# Altium schematic sheet Slave.~(35).~(1).SchDoc(Slave.~(35).~(1))
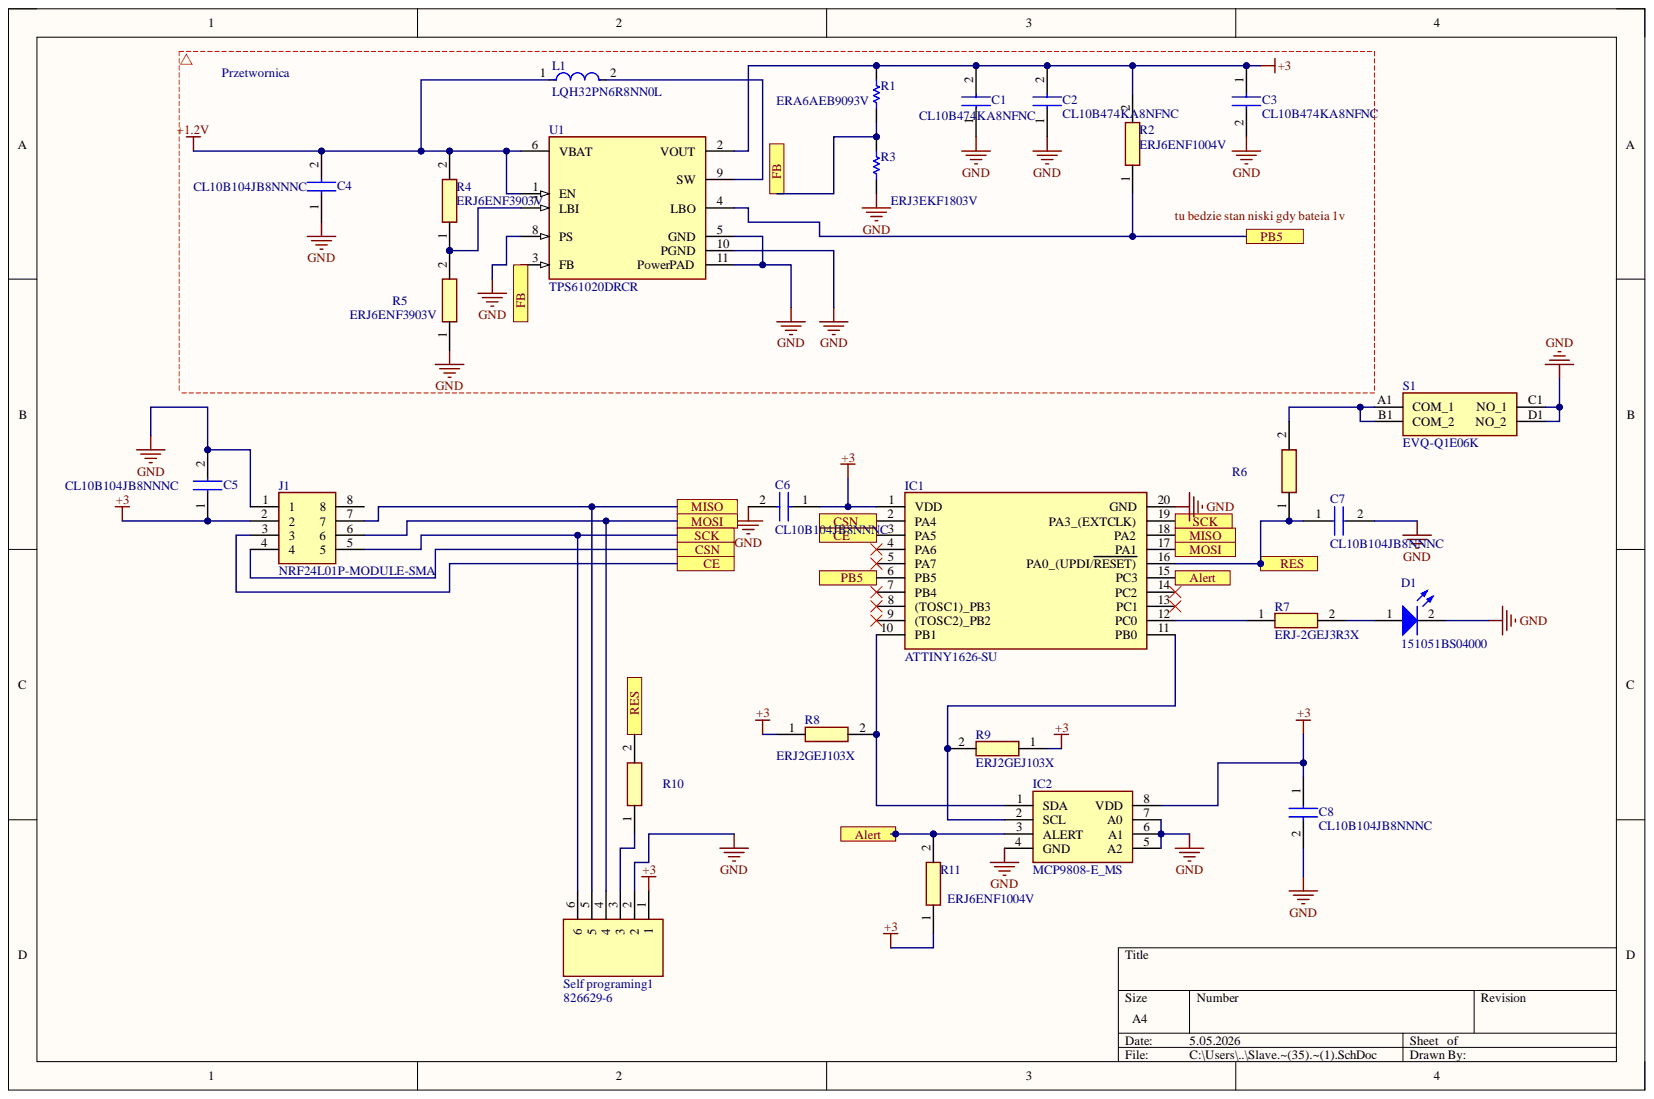
[diagram p0: Slave.~]

## Parts
C1  CL10B474KA8NFNC  [CAPC1608X90N]
C2  CL10B474KA8NFNC  [CAPC1608X90N]
C3  CL10B474KA8NFNC  [CAPC1608X90N]
C4  CL10B104JB8NNNC  [CAPC1608X90N]
C5  CL10B104JB8NNNC  [CAPC1608X90N]
C6  CL10B104JB8NNNC  [CAPC1608X90N]
C7  CL10B104JB8NNNC  [CAPC1608X90N]
C8  CL10B104JB8NNNC  [CAPC1608X90N]
D1  151051BS04000  [WL-TMRC_D5.9H8.7]
IC1  ATTINY1626-SU  [SOIC127P1030X265-20N]
IC2  MCP9808-E_MS  [SOP65P490X110-8N]
J1  NRF24L01P-MODULE-SMA  [NRF24L01PMODULESMA]
L1  LQH32PN6R8NN0L  [FP-LQH32PB_N0-MFG]
R1  ERA6AEB9093V  [RESC2013X60X40NL20T25]
R2  ERJ6ENF1004V  [ERJ6ENF1000V]
R3  ERJ3EKF1803V  [RESC1608X55X30LL15T15]
R4  ERJ6ENF3903V  [ERJ6ENF1000V]
R5  ERJ6ENF3903V  [ERJ6ENF1000V]
R6  ERJ6ENF3903V  [ERJ6ENF1000V]
R7  ERJ-2GEJ3R3X  [ERJ2RKD1004X]
R8  ERJ2GEJ103X  [ERJ2RKD1004X]
R9  ERJ2GEJ103X  [ERJ2RKD1004X]
R10  ERJ6ENF3903V  [ERJ6ENF1000V]
R11  ERJ6ENF1004V  [ERJ6ENF1000V]
S1  EVQ-Q1E06K  [EVQQ1E06K]
Self_programing1  826629-6  [HDRV6W63P0X254_1X6_1524X250X950P]
U1  TPS61020DRCR  [FP-DRC0010J-IPC_A]

## Ports
Alert, CE, CSN, FB, MISO, MOSI, PB5, RES, SCK

## Nets
+1.2V: C4.2 L1.1 R4.2 U1.1(EN) U1.6(VBAT)
+3: C1.2 C2.2 C3.1 C5.1 C6.1 C8.1 IC1.1(VDD) IC2.8(VDD) J1.2 R1.2 R2.2 R8.1 R9.1 R11.1 Self_programing1.1 U1.2(VOUT)
GND: C1.1 C2.1 C3.2 C4.1 C5.2 C6.2 C7.2 C8.2 D1.2 IC1.20(GND) IC2.4(GND) IC2.5(A2) IC2.6(A1) IC2.7(A0) J1.1 R3.1 R5.1 S1.C1(NO_1) S1.D1(NO_2) Self_programing1.2 U1.5(GND) U1.8(PS) U1.10(PGND) U1.11(PowerPAD)
Alert: IC1.15(PC3) IC2.3(ALERT) R11.2 ; port Alert
CE: IC1.3(PA5) J1.3 ; port CE
CSN: IC1.2(PA4) J1.4 ; port CSN
FB: R1.1 R3.2 U1.3(FB) ; port FB
MISO: IC1.18(PA2) J1.7 Self_programing1.5 ; port MISO
MOSI: IC1.17(PA1) J1.6 Self_programing1.4 ; port MOSI
PB5: IC1.6(PB5) R2.1 U1.4(LBO) ; port PB5
RES: C7.1 IC1.16(PA0_(UPDI/RESET)) R6.1 R10.2 ; port RES
SCK: IC1.19(PA3_(EXTCLK)) J1.5 Self_programing1.6 ; port SCK
NetD1_1: D1.1 R7.2
NetIC1_10: IC1.10(PB1) IC2.1(SDA) R8.2
NetIC1_11: IC1.11(PB0) IC2.2(SCL) R9.2
NetIC1_12: IC1.12(PC0) R7.1
NetL1_2: L1.2 U1.9(SW)
NetR4_1: R4.1 R5.2 U1.7(LBI)
NetR6_2: R6.2 S1.A1(COM_1) S1.B1(COM_2)
NetR10_1: R10.1 Self_programing1.3
tu bedzie stan niski gdy bateia 1v:

Not connected: IC1 4(PA6) 5(PA7) 7(PB4) 8((TOSC1)_PB3) 9((TOSC2)_PB2) 13(PC1) 14(PC2); J1 8

## Library data of the parts
C1-C3 = CL10B474KA8NFNC: Capacitor; Manufacturer_Name: SAMSUNG; Arrow Part Number: CL10B474KA8NFNC; Arrow Price/Stock: https://www.arrow.com/en/products/cl10b474ka8nfnc/samsung-electro-mechanics; Component Kind: Standard; Datasheet Link: https://www.mouser.com/datasheet/2/585/MLCC-1837944.pdf; Height: 0.9mm; Manufacturer_Part_Number: CL10B474KA8NFNC; Simulation: C_Capacitor_Default
C4-C8 = CL10B104JB8NNNC: Capacitor; Manufacturer_Name: SAMSUNG; Arrow Part Number: CL10B104JB8NNNC; Arrow Price/Stock: https://www.arrow.com/en/products/cl10b104jb8nnnc/samsung-electro-mechanics?region=nac; Component Kind: Standard; Datasheet Link: https://datasheet.datasheetarchive.com/originals/distributors/Datasheets_SAMA/dd149ce8a6679b37799cedb31427d43d.pdf; Height: 0.9mm; Manufacturer_Part_Number: CL10B104JB8NNNC; Mouser Part Number: 187-CL10B104JB8NNNC; Mouser Price/Stock: https://www.mouser.co.uk/ProductDetail/Samsung-Electro-Mechanics/CL10B104JB8NNNC?qs=349EhDEZ59oLEw5wc1qvGw%3D%3D
D1 = CMP-1488-00009-2: NexarPartId: 29717812; Color: Blue; Dominant Wavelength: 470nm; Forward Current: 100mA; Forward Voltage: 3.2V; Lens Size: 5mm; Lens Style: Circular, Diffused, Round; Luminous Intensity: 500mcd; Max Reverse Voltage (DC): 5V; Peak Wavelength: 465nm; Test Current: 20mA; Viewing Angle: 40°; Case/Package: Radial; Component Kind: Standard; Height: 8.6mm; Length: 5mm; Library Name: Altium Content Vault; Max Operating Temperature: 85°C; Min Operating Temperature: -40°C; Mount: Through Hole; Packaging: Bulk; REACH SVHC: Unknown; RoHS: Compliant; Wavelength: 470nm; Width: 5mm
IC1 = ATTINY1626-SU: Integrated Circuit; Manufacturer_Name: Microchip; Arrow Part Number: ATTINY1626-SU; Arrow Price/Stock: https://www.arrow.com/en/products/attiny1626-su/microchip-technology?region=nac; Component Kind: Standard; Datasheet Link: http://ww1.microchip.com/downloads/en/DeviceDoc/ATtiny1624-26-27-DataSheet-DS40002234A.pdf; Height: 2.65mm; Manufacturer_Part_Number: ATTINY1626-SU
IC2 = MCP9808-E_MS: Integrated Circuit; Manufacturer_Name: Microchip; Manufacturer_Part_Number: MCP9808-E/MS; Arrow Part Number: MCP9808-E/MS; Arrow Price/Stock: https://www.arrow.com/en/products/mcp9808-ems/microchip-technology?region=nac; Component Kind: Standard; Datasheet Link: https://datasheet.datasheetarchive.com/originals/distributors/Datasheets-DGA18/383780.pdf; Height: 1.1mm
J1 = NRF24L01P-MODULE-SMA: Connector; Manufacturer_Name: Nordic Semiconductor; Arrow Part Number: NRF24L01P-MODULE-SMA; Arrow Price/Stock: https://www.arrow.com/en/products/nrf24l01p-module-sma/nordic-semiconductor?region=nac; Component Kind: Standard; Datasheet Link: https://infocenter.nordicsemi.com/pdf/nRF24L01P_PS_v1.0.pdf; Height: 4mm; Manufacturer_Part_Number: NRF24L01P-MODULE-SMA
L1 = CMP-06042-004619-1: Wire Wound Ferrite Inductor for Power Lines 6.8μH ±30% 0.288Ω 850mA 1210; DC Resistance (DCR): 240mR; Inductance: 6.8uH; Self Resonant Frequency: 40MHz; Shielding: Shielded; Test Frequency: 1MHz; Case Code (Imperial): 1210; Case Code (Metric): 3225; Case/Package: 1210; Component Kind: Standard; Core Material: Ferrite; Current Rating: 850mA; DC Current: 850mA; Depth: 2.8mm; Height: 2mm; Height - Seated (Max): 0.067inch; Lead Free: Lead Free; Length: 3.2mm; Library Name: Altium Content Vault; Max DC Current: 850mA; Max Operating Temperature: 85°C; Military Standard: Not; Min Operating Temperature: -40°C; Mount: Surface Mount; Package Quantity: 2000; Packaging: Tape and Reel; Radiation Hardening: No; Resistor Type: Wirewound; Saturation Current: 850mA; Termination: SMD/SMT; Tolerance: 30%; Weight: 0.001552oz; Width: 2.5mm
R1 = CMP-2001-00893-1: Manufacturer: Panasonic; Component Kind: Standard; Library Name: Altium Content Vault; Part Number: ERA6AEB9093V
R2 R11 = ERJ6ENF1004V: Resistor; Manufacturer_Name: Panasonic; Component Kind: Standard; Datasheet Link: http://docs-europe.electrocomponents.com/webdocs/13ba/0900766b813ba21b.pdf; Height: 0.7mm; Manufacturer_Part_Number: ERJ6ENF1004V; Simulation: R_Resistor_Default
R3 = CMP-2000-00296-1: Manufacturer: Panasonic; Component Kind: Standard; Library Name: Altium Content Vault; Part Number: ERJ3EKF1803V
R4-R6 R10 = ERJ6ENF3903V: Resistor; Manufacturer_Name: Panasonic; Component Kind: Standard; Datasheet Link: http://docs-europe.electrocomponents.com/webdocs/13ba/0900766b813ba21b.pdf; Height: 0.7mm; Manufacturer_Part_Number: ERJ6ENF3903V; Simulation: R_Resistor_Default
R7-R9 = ERJ-2GEJ3R3X: Resistor; Manufacturer_Name: Panasonic; Arrow Part Number: ERJ-2GEJ3R3X; Arrow Price/Stock: https://www.arrow.com/en/products/erj-2gej3r3x/panasonic; Component Kind: Standard; Datasheet Link: https://datasheet.datasheetarchive.com/originals/distributors/DKDS40/DSANUWW0010666.pdf; Height: 0.4mm; Manufacturer_Part_Number: ERJ-2GEJ3R3X; Mouser Part Number: 667-ERJ-2GEJ3R3X; Mouser Price/Stock: https://www.mouser.co.uk/ProductDetail/Panasonic/ERJ-2GEJ3R3X?qs=YMBIwoNQYdWx1%2FesbGSybw%3D%3D
S1 = EVQ-Q1E06K: Switch; Manufacturer_Name: Panasonic; Arrow Part Number: EVQ-Q1E06K; Arrow Price/Stock: https://www.arrow.com/en/products/evq-q1e06k/panasonic; Component Kind: Standard; Datasheet Link: https://b2b-api.panasonic.eu/file_stream/pids/fileversion/245489; Height: 6.7mm; Manufacturer_Part_Number: EVQ-Q1E06K; Mouser Part Number: 667-EVQ-Q1E06K; Mouser Price/Stock: https://www.mouser.co.uk/ProductDetail/Panasonic/EVQ-Q1E06K?qs=iurMsj5%2FK0sLNNXJSdosvw%3D%3D
Self_programing1 = 826629-6: Connector; Manufacturer_Name: TE Connectivity; Arrow Part Number: 826629-6; Arrow Price/Stock: https://www.arrow.com/en/products/826629-6/te-connectivity?region=europe; Component Kind: Standard; Datasheet Link: https://componentsearchengine.com/Datasheets/2/826629-6.pdf; Height: 9.5mm; Manufacturer_Part_Number: 826629-6
U1 = CMP-0343-00022-3: IC REG BOOST ADJ 1.5A 10VSON; Current Rating: 25mA; Efficiency: 96%; Max Input Voltage: 6.5V; Max Output Current: 1.2A; Max Output Voltage: 5.5V; Min Input Voltage: 900mV; Min Output Voltage: 1.8V; Number of Outputs: 1; Output Type: Adjustable; Quiescent Current: 25uA; Switching Frequency: 720kHz; Synchronous Rectifier: Yes; Component Kind: Standard; Height: 0.9mm; Lead Free: Lead Free; Length: 3mm; Library Name: Altium Content Vault; Max Operating Temperature: 85°C; Min Operating Temperature: -40°C; Number of Pins: 10; Operating Supply Voltage: 6.5V; Output Current: 1.5A; Output Voltage: 5.5V; Package Quantity: 3000; Packaging: Tape and Reel; Radiation Hardening: No; REACH SVHC: No SVHC; RoHS Compliant: Yes; Topology: Boost; Weight: 0.000885oz; Width: 3mm
